# Altium schematic sheet [03] - Mikeduino SCHEMATIC.SchDoc("[03] - Mikeduino SCHEMATIC") (continued)

## Library data of the parts
C1 C2 = EEA-GA1A101B: CAP ALUM 100UF 20% 10V RADIAL; Manufacturer: Panasonic; Ripple Current: 110mA; Life (Hours): 1000Hour; Leakage Current: 10uA; Voltage Rating: 10V; Tolerance: 20%; Termination: Radial; Supplier Part Number 1: P15782CT-ND; Supplier 1: Digi-Key; Stock 1: 5678; Radiation Hardening: No; Pricing 1: 1=0.35, 10=0.238, 100=0.1347, 500=0.0951, 1000=0.0832 (USD); Packaging: Tape and Reel; Package Quantity: 1; Mount: Through Hole; Min Operating Temperature: -55°C; Max Operating Temperature: 105°C; Manufacturer Part Number 1: EEA-GA1A101B; Library Name: 28Pins_Project_V1I1.SchLib; Length: 7mm; Lead Pitch: 5mm; Height - Seated (Max): 0.276inch; Height: 7mm; Features: General Purpose; Diameter: 6.3mm; Description 1: CAP ALUM 100UF 20% 10V RADIAL; Contact Plating: Tin; Component Kind: Standard; Case/Package: Radial
  C1: Voltage Rating (DC): 10V
C3 C12 C13 C19 C21 C22 C34 = CL21A106KPCLQNC: Cap Ceramic 10uF 10V X5R 10% SMD 0805 85C Cardboard T/R; Manufacturer: Samsung; Width: 0.049inch; Tolerance: 10%; Thickness: 0.037inch; Supplier Part Number 1: 1276-2402-6-ND; Supplier 1: Digi-Key; Stock 1: 40000; Radiation Hardening: No; Pricing 1: 1=0.23, 10=0.16, 100=0.0811, 500=0.0555, 1000=0.0491 (USD); Packaging: Tape and Reel; Package Quantity: 1; Mount: Surface Mount; Min Operating Temperature: -55°C; Max Operating Temperature: 85°C; Manufacturer Part Number: CL21A106KPCLQNC; Library Name: 28Pins_Project_V1I1.SchLib; Length: 2mm; Height: 0.85mm; Dielectric: X5R; Depth: 1.25mm; Contact Plating: Tin; Component Kind: Standard; Case Code (Metric): 2012; Case Code (Imperial): 0805; Case/Package: 0805
  C3: Voltage Rating: 10V
C4-C6 C8 C11 C14-C17 C20 C23 C24 C26 C28-C33 = CEU4J2X7R1H104K125AE: TDK         CEU4J2X7R1H104K125AE             SMD Multilayer Ceramic Capacitor, 0805 [2012 Metric], 0.1 F, 50 V,  10%, X7R, CEU Series; Voltage Rating (DC): 50V; Width(mm): 1.2; Weight: 0.000194oz; Voltage Rating: 50V; Tolerance: 10%; Thickness: 0.057inch; Termination: SMD/SMT; Supplier Part Number 1: 445-7856-2-ND; Supplier 1: Digi-Key; Stock 1: 0; REACH SVHC: No SVHC; Pricing 1: 2000=0.108 (USD); Packaging: Tape and Reel; Package Quantity: 1; Mount: Surface Mount; Min Operating Temperature: -55°C; Max Operating Temperature: 125°C; Manufacturer Part Number: CEU4J2X7R1H104K125AE; Manufacturer: TDK; Length(mm): 2; Dielectric: X7R; Depth: 1.25mm; Composition: Ceramic; ComponentLink4URL: https://datasheet.ciiva.com/6257/tdk-tj-car-e-0711-6257710.pdf; ComponentLink4Description: https://datasheet.ciiva.com/6257/tdk-tj-car-e-0711-6257710.pdf; ComponentLink3URL: https://datasheet.ciiva.com/6257/tdk-tj-car-e-0711-6257707.pdf; ComponentLink3Description: https://datasheet.ciiva.com/6257/tdk-tj-car-e-0711-6257707.pdf; ComponentLink2URL: https://datasheet.ciiva.com/6257/tdk-tj-car-e-0711-6257705.pdf; ComponentLink2Description: https://datasheet.ciiva.com/6257/tdk-tj-car-e-0711-6257705.pdf; ComponentLink1URL: https://datasheet.ciiva.com/24219/ceu4j2x7r1h104k125ae-265602-24219969.pdf; ComponentLink1Description: https://datasheet.ciiva.com/24219/ceu4j2x7r1h104k125ae-265602-24219969.pdf; Component Kind: Standard; Category: Capacitors; Case Code (Metric): 2012; Case Code (Imperial): 0805; Case/Package: 0805; Capacitance: 100nF; Library Name: Mikeduino.SchLib
C7 = C2012X5R1E105K125AA: CAP CER 1UF 25V 10% X5R 0805; Manufacturer: TDK Corporation; Capacitance: 1µF; .Creator: MM; .Checked SCH: RF; Tolerance: ±10%; Thickness (Max): 0.057" (1.45mm); Temperature Coefficient: X5R; Supplier Part Number 1: 445-1419-1-ND; Supplier 1: Digi-Key; Stock 1: 57052; Size / Dimension: 0.079" L x 0.049" W (2.00mm x 1.25mm); RoHS: RoHS Compliant; Ratings: -; Pricing 1: 1=0.16, 10=0.112, 100=0.0533, 250=0.04488, 500=0.03758, 1000=0.03025 (USD); Packaging: Cut Tape (CT); Package / Case: 0805 (2012 Metric); Operating Temperature: -55°C ~ 85°C; Mounting Type: Surface Mount, MLCC; Manufacturer Part Number: C2012X5R1E105K125AA; Library Name: 28Pins_Project_V1I1.SchLib; Lead Style: -; Lead Spacing: -; Height - Seated (Max): -; Features: -; ComponentLink1URL: http://product.tdk.com/en/catalog/datasheets/mlcc_commercial_general_en.pdf; ComponentLink1Description: http://product.tdk.com/en/catalog/datasheets/mlcc_commercial_general_en.pdf; Component Kind: Standard; Category: Capacitors; Applications: General Purpose; .Checked PCB: RF
C9 C10 C25 C27 = C0805C180J5GAC7800: KEMET 18pF Multilayer Ceramic Capacitor (MLCC) 50 V dc 5% C0G dielectric C SMD max op. temp. +125C; Voltage Rating (DC): 50V; Temperature Coefficient: 30ppm/°C; Voltage: 50V; Tolerance: 5%; Termination: SMD/SMT; Supplier Part Number 1: 399-1112-6-ND; Supplier 1: Digi-Key; Stock 1: 0; Radiation Hardening: No; Pricing 1: 1=0.16, 10=0.113, 100=0.0532, 500=0.0373, 1000=0.0309 (USD); Packaging: Tape and Reel; Mount: Surface Mount; Min Operating Temperature: -55°C; Max Operating Temperature: 125°C; Manufacturer Part Number 1: C0805C180J5GAC7800; Manufacturer: KEMET; Library Name: 28Pins_Project_V1I1.SchLib; Length: 2mm; Lead Free: Lead Free; Height: 0.78mm; Dielectric Material: Ceramic; Dielectric: C0G; Description 1: KEMET 18pF Multilayer Ceramic Capacitor (MLCC) 50 V dc 5% C0G dielectric C SMD max op. temp. +125C; Depth: 1.25mm; Contact Plating: Matte,Tin; Component Kind: Standard; Case/Package: 0805; Capacitance: 18pF
D1 = LG L29K-G2J1-24-Z: LED SMARTLED GREEN 570NM 0603; Manufacturer: OSRAM Opto Semiconductors Inc; Wavelength - Peak: 572nm; Voltage - Output: 2.5V; Voltage - Input: 2.6 V ~ 6 V; Voltage - Forward (Vf) Typ: 1.7V; Voltage - Dropout (Typical): 0.16V @ 500mA; Viewing Angle: 160°; Regulator Topology: Positive Fixed; Number of Regulators: 1; Millicandela Rating: 3.92mcd; Luminous Flux @ Current - Test: 12 mlm; Lens Type: Diffused; Lens Style/Size: Rectangle with Flat Top, 1.3mm x 0.8mm; Current - Test: 2mA; Current - Output: 500mA; Current - Limit (Min): 600mA; .Checked SCH: used; Wavelength - Dominant: 570nm; Value: Green; Supplier Part Number 1: 475-2709-1-ND; Supplier Part Number: 475-2709-1-ND; Supplier Device Package: 6-TMLF® (1.6x1.6); Supplier 1: Digi-Key; Supplier: Digikey; Stock 1: 30318; Size / Dimension: 1.70mm L x 0.80mm W; RoHS: RoHS Compliant; Pricing 1: 1=0.16, 10=0.137, 100=0.1093, 250=0.09564, 500=0.08744 (USD); Price 1k [$]: 0.08744; Packaging: Cut Tape (CT); Package / Case: 0603 (1608 Metric); Operating Temperature: -40°C ~ 125°C; Mounting Type: Surface Mount; Manufacturer Part Number: LG L29K-G2J1-24-Z; Library Name: 28Pins_Project_V1I1.SchLib; Height: 0.65mm; Family: LEDs - <75mA, Discre; ComponentLink2URL: http://www.micrel.com/_PDF/mic5353.pdf; ComponentLink2Description: http://www.micrel.com/_PDF/mic5353.pdf; ComponentLink1URL: http://catalog.osram-os.com/catalogue/catalogue.do;jsessionid=32CB61882C089EDD4B6D0A54E7E1F117?act=downloadFile&favOid=020000020000138e000100b6; ComponentLink1Description: http://catalog.osram-os.com/catalogue/catalogue.do;jsessionid=32CB61882C089EDD4B6D0A54E7E1F117?act=downloadFile&favOid=020000020000138e000100b6; Component Kind: Standard; Color: Green; Category: Optoelectronics; .Checked PCB: used
D2-D4 = LO L29K-H2K1-24-Z: LED SMARTLED ORANGE 606NM 0603; Manufacturer: OSRAM Opto Semiconductors Inc; Wavelength - Peak: 610nm; Voltage - Forward (Vf) Typ: 1.8V; Viewing Angle: 160°; Millicandela Rating: 6.3mcd; Luminous Flux @ Current - Test: 25 mlm; Lens Type: Diffused; Lens Style/Size: Rectangle with Flat Top, 1.3mm x 0.8mm; Current - Test: 2mA; .Checked SCH: used; Wavelength - Dominant: 606nm; Supplier Part Number 1: 475-2740-1-ND; Supplier 1: Digi-Key; Stock 1: 15900; Size / Dimension: 1.70mm L x 0.80mm W; RoHS: RoHS Compliant; Pricing 1: 1=0.17, 10=0.141, 100=0.1127, 250=0.0986, 500=0.09016 (USD); Packaging: Cut Tape (CT); Package / Case: 0603 (1608 Metric); Mounting Type: Surface Mount; Manufacturer Part Number: LO L29K-H2K1-24-Z; Library Name: 28Pins_Project_V1I1.SchLib; Height: 0.65mm; ComponentLink1URL: http://catalog.osram-os.com/catalogue/catalogue.do;jsessionid=302CF83AF637AE24E40AEB0ADA267EDD?act=downloadFile&favOid=020000010003f9de000100b6; ComponentLink1Description: http://catalog.osram-os.com/catalogue/catalogue.do;jsessionid=302CF83AF637AE24E40AEB0ADA267EDD?act=downloadFile&favOid=020000010003f9de000100b6; Component Kind: Standard; Color: Orange; Category: Optoelectronics; .Checked PCB: used
D5 D6 = BAT54HT1G: DIODE SCHOTTKY 30V 0.2A SOD323; Manufacturer: Fairchild Semiconductor; Voltage - Forward (Vf) (Max) @ If: 800mV @ 100mA; Thermal Resistance: 600°C/W Ja; Speed: Small Signal =< 200mA (Io), Any Speed; Reverse Recovery Time (trr): 5ns; Current - Reverse Leakage @ Vr: 2µA @ 25V; Current - Average Rectified (Io): 200mA; Capacitance @ Vr, F: 10pF @ 1V, 1MHz; .Creator: MM; .Checked SCH: used; Voltage - DC Reverse (Vr) (Max): 30V; Supplier Part Number 1: BAT54HT1GCT-ND; Supplier Device Package: SOD-323; Supplier 1: Digi-Key; Stock 1: 11388; RoHS: RoHS Compliant; Pricing 1: 1=0.3, 10=0.276, 25=0.1984, 100=0.1543, 250=0.09696, 500=0.0826, 1000=0.05628 (USD); Packaging: Cut Tape (CT); Package / Case: SC-76, SOD-323; Operating Temperature - Junction: -55°C ~ 150°C; Mounting Type: Surface Mount; Manufacturer Part Number: BAT54HT1G; Library Name: 28Pins_Project_V1I1.SchLib; Diode Type: Schottky; ComponentLink1URL: http://www.fairchildsemi.com/ds/BA/BAT54HT1G.pdf; ComponentLink1Description: http://www.fairchildsemi.com/ds/BA/BAT54HT1G.pdf; Component Kind: Standard; Category: Discrete Semiconductor Products; .Checked PCB: used
E1-E3 = MPZ2012S601AT000: TDK   MPZ2012S601AT000   FERRITE BEAD, 0.1OHM, 2A, 0805, FULL REEL; Impedance: 600R; DC Resistance (DCR): 100mR; Width: 0.049inch; Supplier Part Number 1: 445-2206-6-ND; Supplier 1: Digi-Key; Stock 1: 326529; REACH SVHC: No SVHC; Pricing 1: 1=0.11, 10=0.091, 25=0.0704, 50=0.0584, 100=0.0503, 250=0.0403, 500=0.0362, 1000=0.0302 (USD); Packaging: Tape and Reel; Package Quantity: 1; Mount: Surface Mount; Max DC Current: 2A; Manufacturer Part Number: MPZ2012S601AT000; Manufacturer: TDK; Library Name: 28Pins_Project_V1I1.SchLib; Length: 0.079inch; Lead Free: Lead Free; Height: 0.041inch; Current Rating: 2A; Component Kind: Standard; Case Code (Metric): 2012; Case Code (Imperial): 0805; Case/Package: 0805
FID1-FID4 = FID_1MMC: Fiducial 40mil Diameter, 120mill Mask; Library Name: 28Pins_Project_V1I1.SchLib
J1 J3 = M20-7820842: Headers & Wire Housings 8 PIN SIL VERTICAL SOCKET GOLD+TIN; Manufacturer: Harwin; Pitch: 2.54mm; Number of Positions: 8; Max Current Rating: 3A; Gender: Female; Contact Resistance: 20mR; Connector Type: Receptacle,Socket; Weight: 0.025397oz; Termination: Solder; Supplier 1: Digi-Key; Stock 1: 1833; Radiation Hardening: No; Pricing 1: 1=1.1, 10=0.972, 100=0.8382, 500=0.6858, 1000=0.6096, 5000=0.5334, 10000=0.5067 (USD); Packaging: Rail/Tube; Package Quantity: 29; Orientation: Straight; Number of Rows: 1; Number of Contacts: 8; Mount: Through Hole; Min Operating Temperature: -40°C; Max Operating Temperature: 105°C; Manufacturer Part Number: M20-7820842; Library Name: 28Pins_Project_V1I1.SchLib; Length: 20.72mm; Housing Material: Polyamide; Housing Colour: Black; Depth: 2.5mm; Contact Plating: Tin,Gold; Contact Material: Bronze; Contact Gender: Female; ComponentLink2URL: https://datasheet.ciiva.com/9480/m20-782-9480074.pdf; ComponentLink2Description: https://datasheet.ciiva.com/9480/m20-782-9480074.pdf; ComponentLink1URL: https://datasheet.ciiva.com/16732/m20-782-16732663.pdf; ComponentLink1Description: https://datasheet.ciiva.com/16732/m20-782-16732663.pdf; Component Kind: Standard; Colour: Black; Case/Package: SIL
J2 = M20-7820646: Headers & Wire Housings 6 PIN SIL VERTICAL SOCKET TIN; Manufacturer: Harwin; Pitch: 2.54mm; Number of Positions: 6; Max Current Rating: 3A; Gender: Female; Contact Resistance: 20mR; Connector Type: Receptacle,Socket; Termination: Solder; Supplier 1: Digi-Key; Stock 1: 3680; Radiation Hardening: No; Pricing 1: 1=0.96, 10=0.842, 100=0.726, 500=0.594, 1000=0.528, 5000=0.462, 10000=0.4389 (USD); Packaging: Rail/Tube; Package Quantity: 38; Orientation: Straight; Number of Rows: 1; Number of Contacts: 6; Mount: Through Hole; Min Operating Temperature: -40°C; Max Operating Temperature: 105°C; Manufacturer Part Number: M20-7820646; Library Name: 28Pins_Project_V1I1.SchLib; Length: 15.64mm; Housing Material: Nylon; Housing Colour: Black; Depth: 2.5mm; Contact Plating: Tin; Contact Material: Bronze; Contact Gender: Female; ComponentLink3URL: http://components.arrow.com/part/dataview/0323_00725884; ComponentLink3Description: http://components.arrow.com/part/dataview/0323_00725884; ComponentLink2URL: https://cdn.harwin.com/pdfs/Harwin_PC_Connectors_M20.pdf; ComponentLink2Description: https://cdn.harwin.com/pdfs/Harwin_PC_Connectors_M20.pdf; ComponentLink1URL: http://www.harwin.com/include/downloads/pdfs/2010PAGE120.PDF; ComponentLink1Description: http://www.harwin.com/include/downloads/pdfs/2010PAGE120.PDF; Component Kind: Standard; Colour: Black; Case/Package: SIL
J4 = M20-7821046: Headers & Wire Housings 10 PIN SIL VERTICAL SOCKET TIN; Manufacturer: Harwin; Pitch: 2.54mm; Number of Positions: 10; Max Current Rating: 3A; Mating Cycles: 50; Insulation Resistance: 1MR; Hole Diameter: 0.8mm; Gender: Receptacle; Contact Resistance: 20mR; Connector Type: Receptacle,Socket; Termination: Solder; Supplier 1: Digi-Key; Stock 1: 339; REACH SVHC: No SVHC; Radiation Hardening: No; Pricing 1: 1=1.28, 10=1.122, 100=0.968, 500=0.792, 1000=0.704, 5000=0.616, 10000=0.5852 (USD); Packaging: Rail/Tube; Package Quantity: 23; Orientation: Straight; Number of Rows: 1; Number of Contacts: 10; Mount: Through Hole; Min Operating Temperature: -40°C; Max Operating Temperature: 105°C; Manufacturer Part Number: M20-7821046; Library Name: 28Pins_Project_V1I1.SchLib; Length: 25.8mm; Lead Pitch: 2.54mm; Housing Material: Polyamide; Housing Colour: Black; Depth: 2.5mm; Current Rating: 3A; Contact Plating: Tin; Contact Material: Bronze; Contact Gender: Female; ComponentLink5URL: https://datasheet.ciiva.com/11753/0900766b80dc1d2b-11753869.pdf; ComponentLink5Description: https://datasheet.ciiva.com/11753/0900766b80dc1d2b-11753869.pdf; ComponentLink4URL: https://datasheet.ciiva.com/16732/m20-782-16732152.pdf; ComponentLink4Description: https://datasheet.ciiva.com/16732/m20-782-16732152.pdf; ComponentLink3URL: https://datasheet.ciiva.com/10151/m20-782-10151366.pdf; ComponentLink3Description: https://datasheet.ciiva.com/10151/m20-782-10151366.pdf; ComponentLink2URL: https://datasheet.ciiva.com/6785/m20-782-6785605.pdf; ComponentLink2Description: https://datasheet.ciiva.com/6785/m20-782-6785605.pdf; ComponentLink1URL: https://datasheet.ciiva.com/26899/getdatasheetpartid-559226-26899379.pdf; ComponentLink1Description: https://datasheet.ciiva.com/26899/getdatasheetpartid-559226-26899379.pdf; Component Kind: Standard; Colour: Black; Case/Package: SIL
J5 J6 = 67997-206HLF: 6 Positions Header, Unshrouded Connector 0.100" (2.54mm) Through Hole Gold or Gold, GXT™; Manufacturer: Amphenol FCI; Voltage Rating: 1.5kV; Row Spacing: 0.1inch; Number of Positions: 6; Mating Post Length: 5.84mm; Insulation Resistance: 5GR; Gender: Male; Current Rating: 3A; Connector Type: Male Pin; Termination Post Length: 3.05mm; Termination: Solder; Supplier Part Number 1: 609-3234-ND; Supplier 1: Digi-Key; Stock 1: 15115; Radiation Hardening: No; Pricing 1: 1=0.32, 10=0.307, 100=0.2146, 500=0.184, 1000=0.1533, 5000=0.1314, 10000=0.1226 (USD); Pitch: 2.54mm; Packaging: Bulk; Package Quantity: 100; Orientation: Straight; Number of Rows: 2; Number of Contacts: 6; Mount: Through Hole; Min Operating Temperature: -65°C; Max Voltage Rating (AC): 1.5kV; Max Operating Temperature: 125°C; Manufacturer Part Number 1: 67997-206HLF; Library Name: 28Pins_Project_V1I1.SchLib; Length: 7.62mm; Housing Material: Thermoplastic; Housing Colour: Black; Flammability Rating: UL94 V-0; Description 1: 6 Positions Header, Unshrouded Connector 0.100" (2.54mm) Through Hole Gold or Gold, GXT™; Depth: 4.83mm; Contact Plating: Gold,GXT; Contact Mating Length: 0.23inch; Contact Material: Bronze; Contact Gender: Male; Component Kind: Standard; Colour: Black
J7 = 10118193-0001LF: CONN USB MICRO B RECPT SMT R/A; Manufacturer: FCI; Specifications: USB 2.0; Number of Contacts: 5; Gender: Receptacle; Connector Type: USB - micro B; .Creator: MM; .Checked PCB: RF; Supplier Part Number 1: 609-4616-1-ND; Supplier 1: Digi-Key; Stock 1: 28642; RoHS: RoHS Compliant; Pricing 1: 1=0.46, 10=0.433, 25=0.3712, 50=0.3156, 100=0.3032, 250=0.27224, 500=0.25988 (USD); Packaging: Cut Tape (CT); Mounting Type: Surface Mount, Right Angle, Horizontal; Manufacturer Part Number: 10118193-0001LF; Library Name: 28Pins_Project_V1I1.SchLib; Ingress Protection: -; Features: Shielded, Solder Retention; ComponentLink1URL: http://portal.fciconnect.com/Comergent//fci/drawing/10118193.pdf; ComponentLink1Description: http://portal.fciconnect.com/Comergent//fci/drawing/10118193.pdf; Component Kind: Standard; Category: Connectors, Interconnects; .Checked SCH: MM
J8 = 67997-206HLF_1_TP: 6 Positions Header, Unshrouded Connector 0.100" (2.54mm) Through Hole Gold or Gold, GXT™; Manufacturer: Amphenol FCI; Voltage Rating: 1.5kV; Row Spacing: 0.1inch; Number of Positions: 6; Mating Post Length: 5.84mm; Insulation Resistance: 5GR; Gender: Male; Current Rating: 3A; Connector Type: Male Pin; Width(mm): 5.05; Termination Post Length: 3.05mm; Termination: Solder; Supplier Part Number 1: 609-3234-ND; Supplier 1: Digi-Key; Stock 1: 15115; Radiation Hardening: No; Pricing 1: 1=0.32, 10=0.307, 100=0.2146, 500=0.184, 1000=0.1533, 5000=0.1314, 10000=0.1226 (USD); Pitch: 2.54mm; Packaging: Bulk; Package Quantity: 100; Orientation: Straight; Number of Rows: 2; Number of Contacts: 6; Mount: Through Hole; Min Operating Temperature: -65°C; Max Voltage Rating (AC): 1.5kV; Max Operating Temperature: 125°C; Manufacturer Part Number 1: 67997-206HLF; Length(mm): 7.62; Housing Material: Thermoplastic; Housing Colour: Black; Flammability Rating: UL94 V-0; Description 1: 6 Positions Header, Unshrouded Connector 0.100" (2.54mm) Through Hole Gold or Gold, GXT™; Depth: 4.83mm; Contact Plating: Gold,GXT; Contact Mating Length: 0.23inch; Contact Material: Bronze; Contact Gender: Male; Component Kind: Standard; Colour: Black; Category: Connectors, Interconnects; Library Name: Mikeduino.SchLib
JP1 JP4 = 68000-103HLF: BERGSTIK II .100" SR STRAIGHT; Manufacturer: FCI; Pitch: 0.100" (2.54mm); Number of Positions Loaded: All; Number of Positions: 3; Connector Type: Header, Unshrouded; .Creator: MM; .Checked SCH: used; Termination: Solder; Supplier Part Number 1: 609-3461-ND; Supplier 1: Digi-Key; Stock 1: 37214; Row Spacing: -; RoHS: RoHS Compliant; Pricing 1: 1=0.15, 10=0.145, 25=0.108, 50=0.0924 (USD); Packaging: Bulk; Number of Rows: 1; Mounting Type: Through Hole; Manufacturer Part Number: 68000-103HLF; Library Name: 28Pins_Project_V1I1.SchLib; Features: -; Fastening Type: -; Contact Type: Male Pin; Contact Mating Length: 0.230" (5.84mm); Contact Finish Thickness: 30µin (0.76µm); Contact Finish: Gold or Gold, GXT™; ComponentLink1URL: http://portal.fciconnect.com/Comergent//fci/drawing/68000.pdf; ComponentLink1Description: http://portal.fciconnect.com/Comergent//fci/drawing/68000.pdf; Component Kind: Standard; Color: Black; Category: Connectors, Interconnects; .Checked PCB: used
JP2 = 68602-204HLF: CONN HEADER 4POS .100 STR 15AU; Manufacturer: FCI; Row Spacing: 0.100" (2.54mm); Number of Positions Loaded: All; Number of Positions: 4; Connector Type: Header, Unshrouded; .Creator: MM; .Checked SCH: RF; Termination: Solder; Supplier Part Number 1: 609-3369-ND; Supplier 1: Digi-Key; Stock 1: 2795; RoHS: RoHS Compliant; Pricing 1: 1=0.34, 10=0.326, 25=0.2792, 50=0.2374, 100=0.2281, 250=0.20484, 500=0.19552, 1000=0.16293, 2500=0.14896 (USD); Pitch: 0.100" (2.54mm); Packaging: Bulk; Number of Rows: 2; Mounting Type: Through Hole; Manufacturer Part Number: 68602-204HLF; Library Name: 28Pins_Project_V1I1.SchLib; Features: -; Fastening Type: -; Contact Type: Male Pin; Contact Mating Length: 0.230" (5.84mm); Contact Finish Thickness: 15µin (0.38µm); Contact Finish: Gold or Gold, GXT™; ComponentLink1URL: http://portal.fciconnect.com/Comergent//fci/drawing/67996.pdf; ComponentLink1Description: http://portal.fciconnect.com/Comergent//fci/drawing/67996.pdf; Component Kind: Standard; Color: Black; Category: Connectors, Interconnects; .Checked PCB: RF
JP3 = 68001-204HLF: CONN HEADER 4POS .100 STR 15AU; Manufacturer: FCI; Pitch: 0.100" (2.54mm); Number of Positions Loaded: All; Number of Positions: 4; Connector Type: Header, Unshrouded; .Checked SCH: RF; Termination: Solder; Supplier Part Number 1: 609-3402-ND; Supplier 1: Digi-Key; Stock 1: 8754; Row Spacing: -; RoHS: RoHS Compliant; Pricing 1: 1=0.7, 10=0.432, 100=0.2485, 250=0.21868, 500=0.1988, 1000=0.17892, 5000=0.12674 (USD); Packaging: Bulk; Number of Rows: 1; Mounting Type: Through Hole; Manufacturer Part Number: 68001-204HLF; Library Name: 28Pins_Project_V1I1.SchLib; Features: -; Fastening Type: -; Contact Type: Male Pin; Contact Mating Length: 0.230" (5.84mm); Contact Finish Thickness: 15µin (0.38µm); Contact Finish: Gold; ComponentLink1URL: http://portal.fciconnect.com/res/en/pdffiles/doc_search/68000.pdf; ComponentLink1Description: http://portal.fciconnect.com/res/en/pdffiles/doc_search/68000.pdf; Component Kind: Standard; Color: Black; Category: Connectors, Interconnects; .Checked PCB: RF
L1 = L1210R2R2MDWIT: FIXED IND 2.2UH 2A 80 MOHM SMD; Manufacturer: Kemet; Type: Wirewound; Tolerance: ±20%; Shielding: Unshielded; Material - Core: Ferrite; Inductance: 2.2µH; Frequency - Test: 100kHz; Frequency - Self Resonant: 190MHz; DC Resistance (DCR): 80 mOhm; .Creator: MM; .Checked SCH: RF; Supplier Part Number 1: 399-9598-1-ND; Supplier Device Package: 1210; Supplier 1: Digi-Key; Stock 1: 3607; Size / Dimension: 0.126" L x 0.098" W (3.20mm x 2.50mm); RoHS: RoHS Compliant; Q @ Freq: -; Pricing 1: 1=0.52, 10=0.466, 25=0.4372, 50=0.379, 100=0.3499, 250=0.33236, 500=0.30322 (USD); Packaging: Cut Tape (CT); Package / Case: 1210 (3225 Metric); Operating Temperature: -40°C ~ 105°C; Mounting Type: Surface Mount; Manufacturer Part Number: L1210R2R2MDWIT; Library Name: 28Pins_Project_V1I1.SchLib; Height - Seated (Max): 0.106" (2.70mm); Current - Saturation: -; ComponentLink1URL: http://www.kemet.com//kemet/web/homepage/kechome.nsf/vapubfile/Ferrite%20Products%20Catalog/$file/F3115.pdf; ComponentLink1Description: http://www.kemet.com//kemet/web/homepage/kechome.nsf/vapubfile/Ferrite%20Products%20Catalog/$file/F3115.pdf; Component Kind: Standard; Category: Inductors, Coils, Chokes; .Checked PCB: RF
LINK1-LINK3 = QPC02SXGN-RC: CONN JUMPER SHORTING .100" GOLD; Manufacturer: Sullins Connector Solutions; Pitch: 0.100" (2.54mm); Number of Positions or Pins (Grid): 2 (1 x 2); Gender: Female Sockets; .Checked SCH: used; Type: Open Top; Supplier Part Number 1: S9337-ND; Supplier 1: Digi-Key; Stock 1: 23274; RoHS: RoHS Compliant; Pricing 1: 1=0.11, 10=0.066, 25=0.0468, 50=0.0384, 100=0.033, 250=0.02792, 500=0.0258, 1000=0.0228, 2500=0.018 (USD); Packaging: Bulk; Manufacturer Part Number: QPC02SXGN-RC; Height: 0.235" (5.97mm); Contact Finish Thickness: Flash; Contact Finish: Gold; ComponentLink1URL: http://www.sullinscorp.com/drawings/284_SXGN_11510.pdf; ComponentLink1Description: http://www.sullinscorp.com/drawings/284_SXGN_11510.pdf; Color: -; Category: Connectors, Interconnects
  LINK1: Library Name: 28Pins_Project_V1I1.SchLib
  LINK2 LINK3: Library Name: Integrated_Library_FEDEVEL_APR_2015.IntLib
MH1-MH4 = MH_7.4MMNC_3.2MMD: Mounting Hole 7.4mm Diameter (Non Copper), 3.2mm Drill NPTH; Library Name: 28Pins_Project_V1I1.SchLib
R1 = RC0805FR-07100KL: RES SMD 100K OHM 1% 1/8W 0805; Manufacturer: Yageo; Temperature Coefficient: ±100ppm/°C; Power (Watts): 0.125W, 1/8W; .Creator: MM; .Checked SCH: RF; Width(mm): 1.2; Tolerance: ±1%; Supplier Part Number 1: 311-100KCRCT-ND; Supplier Device Package: 0805; Supplier 1: Digi-Key; Stock 1: 1604881; Size / Dimension: 0.079" L x 0.049" W (2.00mm x 1.25mm); RoHS: RoHS Compliant; Resistance (Ohms): 100k; Pricing 1: 1=0.1, 10=0.021, 25=0.0148, 100=0.0084, 250=0.0064, 500=0.0051, 1000=0.00376, 2500=0.00326 (USD); Packaging: Cut Tape (CT); Package / Case: 0805 (2012 Metric); Operating Temperature: -55°C ~ 155°C; Number of Terminations: 2; Manufacturer Part Number: RC0805FR-07100KL; Length(mm): 2; Height: 0.024" (0.60mm); Features: Moisture Resistant; Composition: Thick Film; ComponentLink1URL: http://www.yageo.com/documents/recent/PYu-RC_Group_51_RoHS_L_1.pdf; ComponentLink1Description: http://www.yageo.com/documents/recent/PYu-RC_Group_51_RoHS_L_1.pdf; Component Kind: Standard; Category: Resistors; Library Name: Mikeduino.SchLib; .Checked PCB: RF
R2 R3 R15 R17 R22 = RC0805FR-07560RL: RES SMD 560 OHM 1% 1/8W 0805; Manufacturer: Yageo; Temperature Coefficient: ±100ppm/°C; Resistance (Ohms): 560; Power (Watts): 0.125W, 1/8W; .Creator: MM; .Checked SCH: RF; Width(mm): 1.2; Tolerance: ±1%; Supplier Part Number 1: 311-560CRCT-ND; Supplier Device Package: 0805; Supplier 1: Digi-Key; Stock 1: 53313; Size / Dimension: 0.079" L x 0.049" W (2.00mm x 1.25mm); RoHS: RoHS Compliant; Pricing 1: 1=0.1, 10=0.021, 25=0.0148, 100=0.0084, 250=0.0064, 500=0.0051, 1000=0.00376, 2500=0.00326 (USD); Packaging: Cut Tape (CT); Package / Case: 0805 (2012 Metric); Operating Temperature: -55°C ~ 155°C; Number of Terminations: 2; Manufacturer Part Number: RC0805FR-07560RL; Length(mm): 2; Height: 0.024" (0.60mm); Features: Moisture Resistant; Composition: Thick Film; ComponentLink1URL: http://www.yageo.com/documents/recent/PYu-RC_Group_51_RoHS_L_1.pdf; ComponentLink1Description: http://www.yageo.com/documents/recent/PYu-RC_Group_51_RoHS_L_1.pdf; Component Kind: Standard; Category: Resistors; Library Name: Mikeduino.SchLib; .Checked PCB: RF
R4 R14 R18-R21 = RC0805FR-0710KL: RES SMD 10K OHM 1% 1/8W 0805; Manufacturer: Yageo; Temperature Coefficient: ±100ppm/°C; Power (Watts): 0.125W, 1/8W; .Creator: MM; .Checked SCH: RF; Width(mm): 1.2; Tolerance: ±1%; Supplier Part Number 1: 311-10.0KCRCT-ND; Supplier Device Package: 0805; Supplier 1: Digi-Key; Stock 1: 4094384; Size / Dimension: 0.079" L x 0.049" W (2.00mm x 1.25mm); RoHS: RoHS Compliant; Resistance (Ohms): 10k; Pricing 1: 1=0.1, 10=0.021, 25=0.0148, 100=0.0084, 250=0.0064, 500=0.0051, 1000=0.00376, 2500=0.00326 (USD); Packaging: Cut Tape (CT); Package / Case: 0805 (2012 Metric); Operating Temperature: -55°C ~ 155°C; Number of Terminations: 2; Manufacturer Part Number: RC0805FR-0710KL; Length(mm): 2; Height: 0.024" (0.60mm); Features: Moisture Resistant; Composition: Thick Film; ComponentLink1URL: http://www.yageo.com/documents/recent/PYu-RC_Group_51_RoHS_L_1.pdf; ComponentLink1Description: http://www.yageo.com/documents/recent/PYu-RC_Group_51_RoHS_L_1.pdf; Component Kind: Standard; Category: Resistors; Library Name: Mikeduino.SchLib; .Checked PCB: RF
R5-R7 R13 R23-R25 = RC0805FR-0722RL: RES SMD 22 OHM 1% 1/8W 0805; Manufacturer: Yageo; Temperature Coefficient: ±100ppm/°C; Resistance (Ohms): 22; Power (Watts): 0.125W, 1/8W; .Creator: MM; .Checked SCH: RF; Width(mm): 1.2; Tolerance: ±1%; Supplier Part Number 1: 311-22.0CRCT-ND; Supplier Device Package: 0805; Supplier 1: Digi-Key; Stock 1: 63849; Size / Dimension: 0.079" L x 0.049" W (2.00mm x 1.25mm); RoHS: RoHS Compliant; Pricing 1: 1=0.1, 10=0.021, 25=0.0148, 100=0.0084, 250=0.0064, 500=0.0051, 1000=0.00376, 2500=0.00326 (USD); Packaging: Cut Tape (CT); Package / Case: 0805 (2012 Metric); Operating Temperature: -55°C ~ 155°C; Number of Terminations: 2; Manufacturer Part Number: RC0805FR-0722RL; Length(mm): 2; Height: 0.024" (0.60mm); Features: Moisture Resistant; Composition: Thick Film; ComponentLink1URL: http://www.yageo.com/documents/recent/PYu-RC_Group_51_RoHS_L_1.pdf; ComponentLink1Description: http://www.yageo.com/documents/recent/PYu-RC_Group_51_RoHS_L_1.pdf; Component Kind: Standard; Category: Resistors; Library Name: Mikeduino.SchLib; .Checked PCB: RF
R8 R26-R28 = RC0805JR-070RL: RES 0.0 OHM 1/8W 0805 SMD; Manufacturer: Yageo; Tolerance: Jumper; Resistance (Ohms): 0.0; Power (Watts): 0.125W, 1/8W; .Checked SCH: RF; Value: 0R; Temperature Coefficient: -; Supplier Part Number 1: 311-0.0ARCT-ND; Supplier Part Number: 311-0.0ARCT-ND; Supplier 1: Digi-Key; Supplier: Digikey; Stock 1: 933202; Size / Dimension: 0.079" L x 0.049" W (2.00mm x 1.25mm); RoHS: RoHS Compliant; Pricing 1: 10=0.017, 50=0.0126, 100=0.0096, 250=0.00716, 500=0.00528, 1000=0.00352 (USD); Price 1k [$]: 0.01479; Packaging: Cut Tape (CT); Package / Case: 0805 (2012 Metric); Number of Terminations: 2; Manufacturer Part Number: RC0805JR-070RL; Library Name: 28Pins_Project_V1I1.SchLib; Height: 0.024" (0.60mm); Features: -; Composition: Thick Film; ComponentLink1URL: http://www.yageo.com/documents/recent/PYu-RC0805_51_RoHS_L_4.pdf; ComponentLink1Description: http://www.yageo.com/documents/recent/PYu-RC0805_51_RoHS_L_4.pdf; Component Kind: Standard; Category: Resistors; .Checked PCB: RF
R9 R16 = RC0805FR-071ML: RES SMD 1M OHM 1% 1/8W 0805; Manufacturer: Yageo; Voltage Rating: 150V; Temperature Coefficient: 100ppm/°C; Resistance: 1MR; Power Rating: 125mW; Width(mm): 1.2; Tolerance: 1%; Termination: SMD/SMT; Supplier Part Number 1: 311-1.00MCRTR-ND; Supplier 1: Digi-Key; Stock 1: 0; REACH SVHC: Unknown; Packaging: Tape and Reel; Package Quantity: 1; Number of Terminations: 2; Min Operating Temperature: -55°C; Military Standard: Not; Max Power Dissipation: 125mW; Max Operating Temperature: 155°C; Manufacturer Part Number: RC0805FR-071ML; Length(mm): 2; Lead Free: Lead Free; Height: 0.024inch; Features: Moisture Resistant; Depth: 1.25mm; Composition: Thick Film; Component Kind: Standard; Category: Resistors; Case Code (Metric): 2012; Case Code (Imperial): 0805; Case/Package: 0805; Library Name: Mikeduino.SchLib
R10-R12 = RC0805FR-071KL: RES SMD 1K OHM 1% 1/8W 0805; Manufacturer: Yageo; Temperature Coefficient: ±100ppm/°C; Power (Watts): 0.125W, 1/8W; .Creator: MM; .Checked SCH: RF; Width(mm): 1.2; Tolerance: ±1%; Supplier Part Number 1: 311-1.00KCRCT-ND; Supplier Device Package: 0805; Supplier 1: Digi-Key; Stock 1: 3497185; Size / Dimension: 0.079" L x 0.049" W (2.00mm x 1.25mm); RoHS: RoHS Compliant; Resistance (Ohms): 1k; Pricing 1: 1=0.1, 10=0.021, 25=0.0148, 100=0.0084, 250=0.0064, 500=0.0051, 1000=0.00376, 2500=0.00326 (USD); Packaging: Cut Tape (CT); Package / Case: 0805 (2012 Metric); Operating Temperature: -55°C ~ 155°C; Number of Terminations: 2; Manufacturer Part Number: RC0805FR-071KL; Length(mm): 2; Height: 0.024" (0.60mm); Features: Moisture Resistant; Composition: Thick Film; ComponentLink1URL: http://www.yageo.com/documents/recent/PYu-RC_Group_51_RoHS_L_1.pdf; ComponentLink1Description: http://www.yageo.com/documents/recent/PYu-RC_Group_51_RoHS_L_1.pdf; Component Kind: Standard; Category: Resistors; Library Name: Mikeduino.SchLib; .Checked PCB: RF
RV1 RV2 = CG0603MLC-05E: VARISTOR 0603; Manufacturer: Bourns Inc.; Number of Circuits: 1; Maximum DC Volts: 5VDC; .Creator: MM; .Checked SCH: RF; Width(mm): 0.8; Varistor Voltage (Typ): -; Varistor Voltage (Min): -; Varistor Voltage (Max): -; Supplier Part Number 1: CG0603MLC-05ECT-ND; Supplier 1: Digi-Key; Stock 1: 104704; RoHS: RoHS Compliant; Pricing 1: 1=0.19, 10=0.177, 25=0.164, 50=0.158, 100=0.1472, 250=0.13104, 500=0.1092, 1000=0.10348, 2500=0.09256 (USD); Packaging: Cut Tape (CT); Package / Case: 0603 (1608 Metric); Mounting Type: Surface Mount, MLCV; Maximum AC Volts: -; Manufacturer Part Number: CG0603MLC-05E; Length(mm): 1.7; Energy: -; Current - Surge: -; ComponentLink1URL: http://www.bourns.com/data/global/pdfs/MLC.pdf; ComponentLink1Description: http://www.bourns.com/data/global/pdfs/MLC.pdf; Component Kind: Standard; Category: Circuit Protection; Library Name: Mikeduino.SchLib; .Checked PCB: RF
S1 S2 = 1825910-6: SWITCH TACTILE SPST-NO 0.05A 24V; Manufacturer: TE Connectivity; Switch Travel: 0.25mm; Switch Function: Off-Mom; Outline: 6.00mm x 6.00mm; Operating Force: 160gf; Mechanical Life: 100,000 Cycles; Illumination: Non-Illuminated; Contact Rating @ Voltage: 0.05A @ 24VDC; Actuator Type: Standard; Actuator Orientation: Top Actuated; Actuator Material: Polybutylene Terephthalate (PBT); Actuator Height off PCB, from Pins: 5.00mm; .Creator: MM; .Checked SCH: RF; Width(mm): 6; Termination Style: PC Pin; Supplier Part Number 1: 450-1650-ND; Supplier 1: Digi-Key; Stock 1: 181823; RoHS: RoHS Compliant; Pricing 1: 1=0.1, 10=0.095, 25=0.0912, 50=0.088, 100=0.0831, 250=0.07824, 500=0.07498, 1000=0.05705 (USD); Packaging: Bulk; Operating Temperature: -35°C ~ 85°C; Mounting Type: Through Hole; Manufacturer Part Number: 1825910-6; Length(mm): 7.8; Ingress Protection: -; Illumination Voltage (Nominal): -; Illumination Type, Color: -; Features: -; ComponentLink1URL: http://www.te.com/commerce/DocumentDelivery/DDEController?Action=srchrtrv&DocNm=1825910&DocType=Customer+Drawing&DocLang=English; ComponentLink1Description: http://www.te.com/commerce/DocumentDelivery/DDEController?Action=srchrtrv&DocNm=1825910&DocType=Customer+Drawing&DocLang=English; Component Kind: Standard; Circuit: SPST-NO; Category: Switches; Library Name: Mikeduino.SchLib; .Checked PCB: RF
SCKT_FOR_U1 = 4828-3004-CP: CONN IC DIP SOCKET 28POS TIN; Manufacturer: 3M; Pitch - Post: 0.100" (2.54mm); Number of Positions or Pins (Grid): 28 (2 x 14); Material Flammability Rating: UL94 V-0; Current Rating: 1A; .Creator: MM; .Checked SCH: RF; Type: DIP, 0.3" (7.62mm) Row Spacing; Termination Post Length: -; Termination: Solder; Supplier Part Number 1: 3M5480-ND; Supplier 1: Digi-Key; Stock 1: 6559; RoHS: RoHS Compliant; Pricing 1: 1=0.77, 10=0.72, 25=0.648, 50=0.576, 100=0.552, 250=0.504, 500=0.48, 1000=0.396, 2500=0.36 (USD); Pitch - Mating: 0.100" (2.54mm); Packaging: Tube; Operating Temperature: -25°C ~ 85°C; Mounting Type: Through Hole; Manufacturer Part Number: 4828-3004-CP; Library Name: 28Pins_Project_V1I1.SchLib; Housing Material: Polyester, Glass Filled; Features: Open Frame; Contact Resistance: -; Contact Material - Post: Phosphor Bronze; Contact Material - Mating: Phosphor Bronze; Contact Finish Thickness - Post: 35µin (0.90µm); Contact Finish Thickness - Mating: 35µin (0.90µm); Contact Finish - Post: Tin; Contact Finish - Mating: Tin; ComponentLink1URL: http://multimedia.3m.com/mws/mediawebserver?66666UuZjcFSLXTt4X&Em8&aEVuQEcuZgVs6EVs6E666666--; ComponentLink1Description: http://multimedia.3m.com/mws/mediawebserver?66666UuZjcFSLXTt4X&Em8&aEVuQEcuZgVs6EVs6E666666--; Component Kind: Standard; Category: Connectors, Interconnects; .Checked PCB: RF
U1 = ATMEGA328P-PU: ATMEL         ATMEGA328P-PU            8 Bit Microcontroller, Low Power High Performance, ATmega, 20 MHz, 32 KB, 2 KB, 28 Pins, DIP; Manufacturer: Microchip / Atmel; Watchdog Timer: Yes; RAM Size: 2KB; Peripherals: Brown-out Detect/Reset,POR,PWM,WDT; Oscillator Type: Internal; Number of Timers/Counters: 3; Number of SPI Channels: 1; Number of PWM Channels: 6; Number of Programmable I/O: 23; Min Supply Voltage: 1.8V; Memory Type: FLASH; Memory Size: 32KB; Max Supply Voltage: 5.5V; Max Frequency: 20MHz; Interface: I2C,SPI,UART,USART,2-Wire; Data Bus Width: 8b; Core Architecture: AVR; Width: 6.76mm; Supplier Part Number 1: ATMEGA328P-PU-ND; Supplier 1: Digi-Key; Stock 1: 14491; REACH SVHC: No SVHC; Radiation Hardening: No; Pricing 1: 1=2.14, 25=1.9672, 100=1.7819 (USD); Packaging: Rail/Tube; Package Quantity: 14; Number of Pins: 28; Number of I/Os: 23; Number of I2C Channels: 1; Number of ADC Channels: 6; Mount: Through Hole; Min Operating Temperature: -40°C; Max Operating Temperature: 85°C; Manufacturer Part Number: ATMEGA328P-PU; Library Name: 28Pins_Project_V1I1.SchLib; Length: 37.4mm; Lead Free: Lead Free; Height: 3.28mm; Frequency: 20MHz; Contact Plating: Tin,Matte; ComponentLink6URL: https://datasheet.ciiva.com/29119/155197-da-01-en-microcontr-atmega328p-pu-8bit-pdip-28-29119593.pdf; ComponentLink6Description: https://datasheet.ciiva.com/29119/155197-da-01-en-microcontr-atmega328p-pu-8bit-pdip-28-29119593.pdf; ComponentLink5URL: https://datasheet.ciiva.com/26818/atmega328p-pu-26818578.pdf; ComponentLink5Description: https://datasheet.ciiva.com/26818/atmega328p-pu-26818578.pdf; ComponentLink4URL: https://datasheet.ciiva.com/19457/2139111-19457070.pdf; ComponentLink4Description: https://datasheet.ciiva.com/19457/2139111-19457070.pdf; ComponentLink3URL: https://datasheet.ciiva.com/15213/getdatasheetpartid-318533-15213133.pdf; ComponentLink3Description: https://datasheet.ciiva.com/15213/getdatasheetpartid-318533-15213133.pdf; ComponentLink2URL: https://datasheet.ciiva.com/20168/73-4283-20168380.pdf; ComponentLink2Description: https://datasheet.ciiva.com/20168/73-4283-20168380.pdf; ComponentLink1URL: https://datasheet.ciiva.com/1157/1684409-1157696.pdf; ComponentLink1Description: https://datasheet.ciiva.com/1157/1684409-1157696.pdf; Component Kind: Standard; Case/Package: PDIP
U2 = ATMEGA16U2-AU: ATMEL         ATMEGA16U2-AU            MCU, 8BIT, MEGAAVR, 16MHZ, TQFP-32; Manufacturer: Microchip / Atmel; Watchdog Timer: Yes; RAM Size: 512B; Peripherals: Brown-out Detect/Reset,POR,PWM,WDT; Oscillator Type: Internal; Number of Timers/Counters: 2; Number of SPI Channels: 1; Number of PWM Channels: 5; Number of Programmable I/O: 22; Min Supply Voltage: 2.7V; Memory Type: FLASH; Memory Size: 16KB; Max Supply Voltage: 5.5V; Interface: SPI,Serial,USB,UART,USART; Data Bus Width: 8b; Core Architecture: AVR; Width: 7mm; Supplier 1: Digi-Key; Stock 1: 4703; REACH SVHC: No SVHC; Pricing 1: 1=2.52, 25=2.3176, 100=2.1012 (USD); Packaging: Tray; Package Quantity: 1250; Number of Pins: 32; Number of I/Os: 22; Mount: Surface Mount; Min Operating Temperature: -40°C; Max Operating Temperature: 85°C; Max Frequency: 16MHz; Manufacturer Part Number: ATMEGA16U2-AU; Library Name: 28Pins_Project_V1I1.SchLib; Length: 7mm; Lead Free: Lead Free; Height: 1.05mm; Frequency: 16MHz; Contact Plating: Tin,Matte; ComponentLink2URL: https://datasheet.ciiva.com/22651/doc7799-22651110.pdf; ComponentLink2Description: https://datasheet.ciiva.com/22651/doc7799-22651110.pdf; ComponentLink1URL: https://datasheet.ciiva.com/13036/doc7799-28769-13036800.pdf; ComponentLink1Description: https://datasheet.ciiva.com/13036/doc7799-28769-13036800.pdf; Component Kind: Standard; Case/Package: A
U3 = TL1963A-33DCQR: IC REG LDO 3.3V 1.5A SOT223-6; Manufacturer: Texas Instruments; Voltage - Input: Up to 20V; Voltage - Dropout (Typical): 0.34V @ 1.5A; Regulator Topology: Positive Fixed; Number of Regulators: 1; Current - Limit (Min): 1.6A; .Creator: MM; .Checked SCH: RF; Voltage - Output: 3.3V; Supplier Part Number 1: 296-24534-1-ND; Supplier Device Package: SOT-223-6; Supplier 1: Digi-Key; Stock 1: 1690; RoHS: RoHS Compliant; Pricing 1: 1=3.11, 10=2.786, 25=2.5056, 100=2.2788, 250=2.0628, 500=1.8468, 750=1.674, 1000=1.35 (USD); Packaging: Cut Tape (CT); Package / Case: SOT-223-6; Operating Temperature: -40°C ~ 125°C; Mounting Type: Surface Mount; Manufacturer Part Number: TL1963A-33DCQR; Library Name: 28Pins_Project_V1I1.SchLib; Current - Output: 1.5A; ComponentLink1URL: http://www.ti.com/lit/ds/symlink/tl1963a.pdf; ComponentLink1Description: http://www.ti.com/lit/ds/symlink/tl1963a.pdf; Component Kind: Standard; Category: Integrated Circuits (ICs); .Checked PCB: RF
U4 = NC7SZ00M5X: IC GATE NAND 1CH 2-INP SOT-23-5; Manufacturer: ON Semiconductor / Fairchild; Turn-On Delay Time: 5ns; Schmitt Trigger Input: No; Quiescent Current: 2uA; Propagation Delay: 10ns; Output Current: 32mA; Number of Outputs: 1; Number of Inputs: 2; Min Supply Voltage: 1.65V; Max Supply Voltage: 5.5V; High Level Output Current: -32mA; Width: 1.7mm; Weight: 0.03g; Supplier Part Number 1: NC7SZ00M5XCT-ND; Supplier 1: Digi-Key; Stock 1: 69067; REACH SVHC: No SVHC; Radiation Hardening: No; Pricing 1: 1=0.39, 10=0.292, 100=0.182, 500=0.1245, 1000=0.0958 (USD); Packaging: Tape and Reel; Package Quantity: 1; Number of Terminals: 5; Number of Pins: 5; Number of Output Lines: 1; Number of Input Lines: 2; Number of Gates: 1; Number of Elements: 1; Number of Circuits: 1; Mount: Surface Mount; Min Operating Temperature: -40°C; Max Operating Temperature: 85°C; Manufacturer Part Number 1: NC7SZ00M5X; Low Level Output Current: 32mA; Library Name: 28Pins_Project_V1I1.SchLib; Length: 3mm; Lead Free: Lead Free; Height: 1.3mm; Description 1: IC GATE NAND 1CH 2-INP SOT-23-5; Contact Plating: Matte,Tin; Component Kind: Standard; Case/Package: SOT-23
U5-U7 = SN74AHC1G09DBVR: IC GATE AND SGL 2INP SOT23-5; Manufacturer: Texas Instruments; Voltage - Supply: 2 V ~ 5.5 V; Number of Inputs: 2; Number of Circuits: 1; Max Propagation Delay @ V, Max CL: 7.5ns @ 5V, 50pF; Logic Type: AND Gate; Logic Level - Low: 0.5 V ~ 1.65 V; Logic Level - High: 1.5 V ~ 3.85 V; Current - Quiescent (Max): 1µA; Current - Output High, Low: -, 8mA; .Creator: MM; .Checked SCH: used; Supplier Part Number 1: 296-29202-1-ND; Supplier Device Package: SOT-23-5; Supplier 1: Digi-Key; Stock 1: 3468; RoHS: RoHS Compliant; Pricing 1: 1=0.49, 10=0.345, 25=0.2836, 100=0.2265, 250=0.165, 500=0.134, 1000=0.103 (USD); Packaging: Cut Tape (CT); Package / Case: SC-74A, SOT-753; Operating Temperature: -55°C ~ 125°C; Mounting Type: Surface Mount; Manufacturer Part Number: SN74AHC1G09DBVR; Library Name: 28Pins_Project_V1I1.SchLib; Features: Open Drain; ComponentLink1URL: http://www.ti.com/lit/ds/symlink/sn74ahc1g09.pdf; ComponentLink1Description: http://www.ti.com/lit/ds/symlink/sn74ahc1g09.pdf; Component Kind: Standard; Category: Integrated Circuits (ICs); .Checked PCB: used
Y1 Y2 = ABM7-22.1184MHZ-D2Y-T: Crystals 22.1184MHz 20ppm 18pF -40C+85C; Manufacturer: Abracon; Frequency Stability: 30ppm; ESR (Equivalent Series Resistance): 50R; Width: 0.138inch; Tolerance: 20ppm; Termination: SMD/SMT; Supplier Part Number 1: 535-9844-6-ND; Supplier 1: Digi-Key; Stock 1: 5243; REACH SVHC: Unknown; Radiation Hardening: No; Pricing 1: 1=1, 10=0.886, 50=0.8278, 100=0.7315, 500=0.693 (USD); Packaging: Tape and Reel; Package Quantity: 1; Number of Pins: 2; Mount: Surface Mount; Min Operating Temperature: -40°C; Max Operating Temperature: 85°C; Manufacturer Part Number: ABM7-22.1184MHZ-D2Y-T; Load Capacitance: 18pF; Library Name: 28Pins_Project_V1I1.SchLib; Length: 6mm; Lead Free: Lead Free; Height: 0.055inch; Frequency Tolerance: 20ppm; Frequency: 22.1184MHz; Depth: 3.5mm; Composition: Ceramic; Component Kind: Standard; Case/Package: CSMD
TP1-TP5 = TEST POINT: Library Name: Mikeduino.SchLib
